# Altium schematic sheet Sheet1.SchDoc(Sheet1)
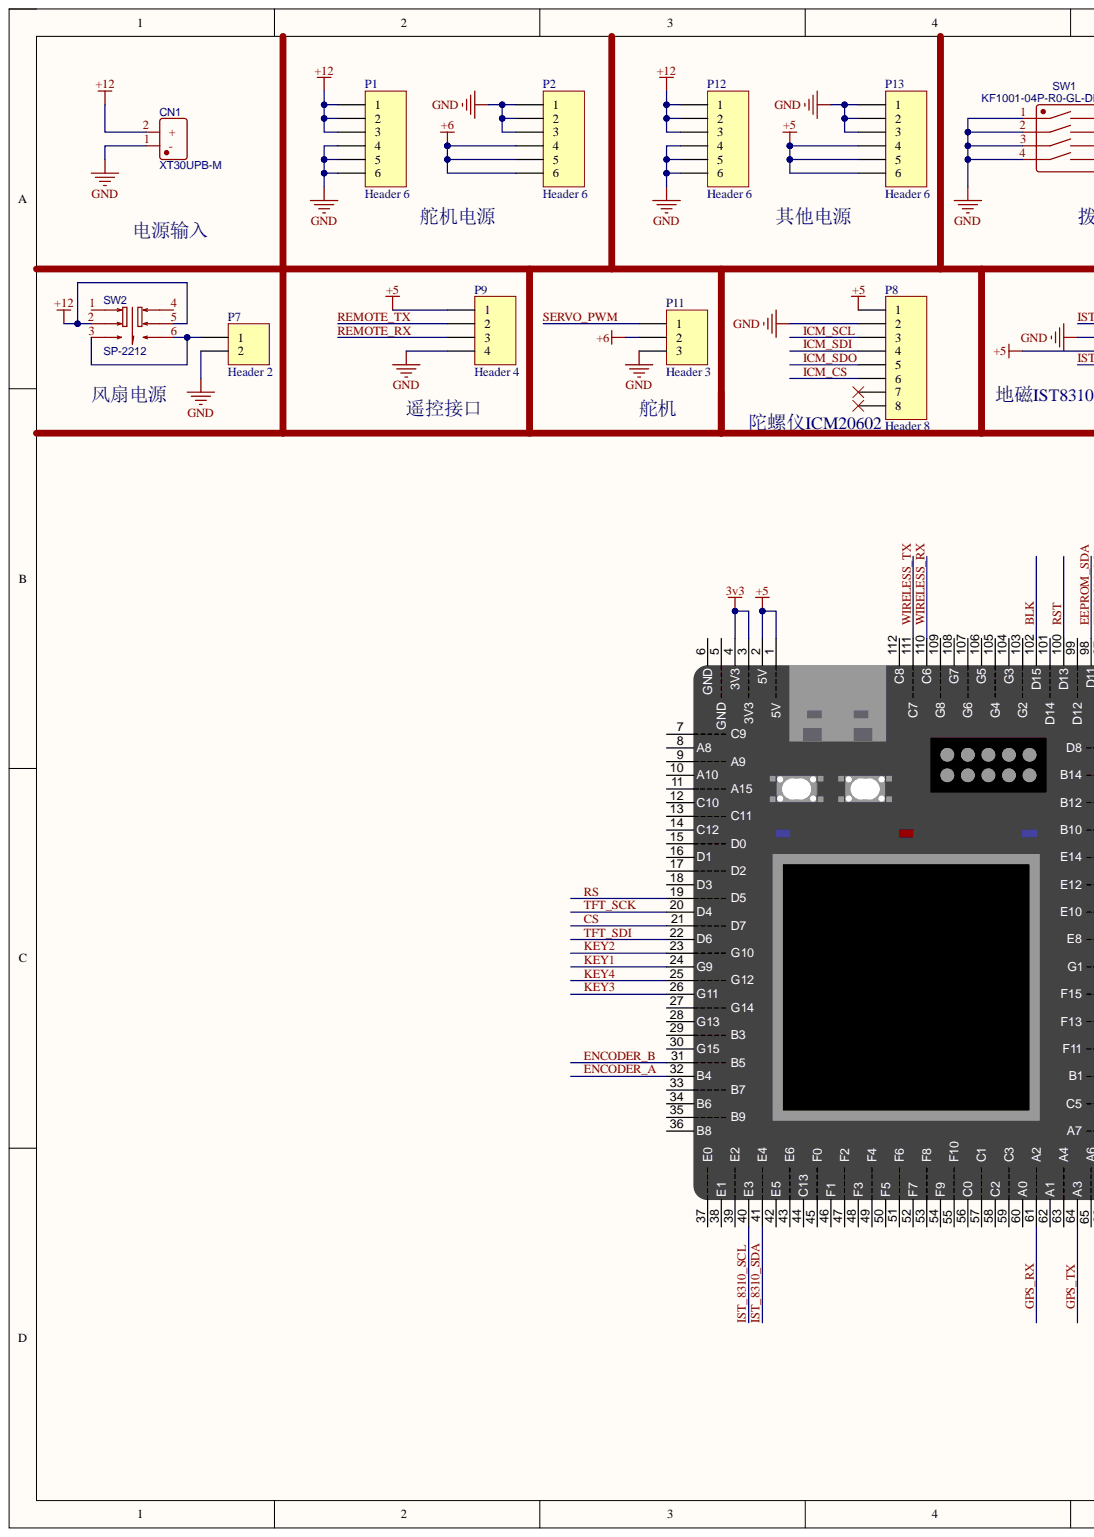
[diagram p0: Sheet1.SchDoc, left]
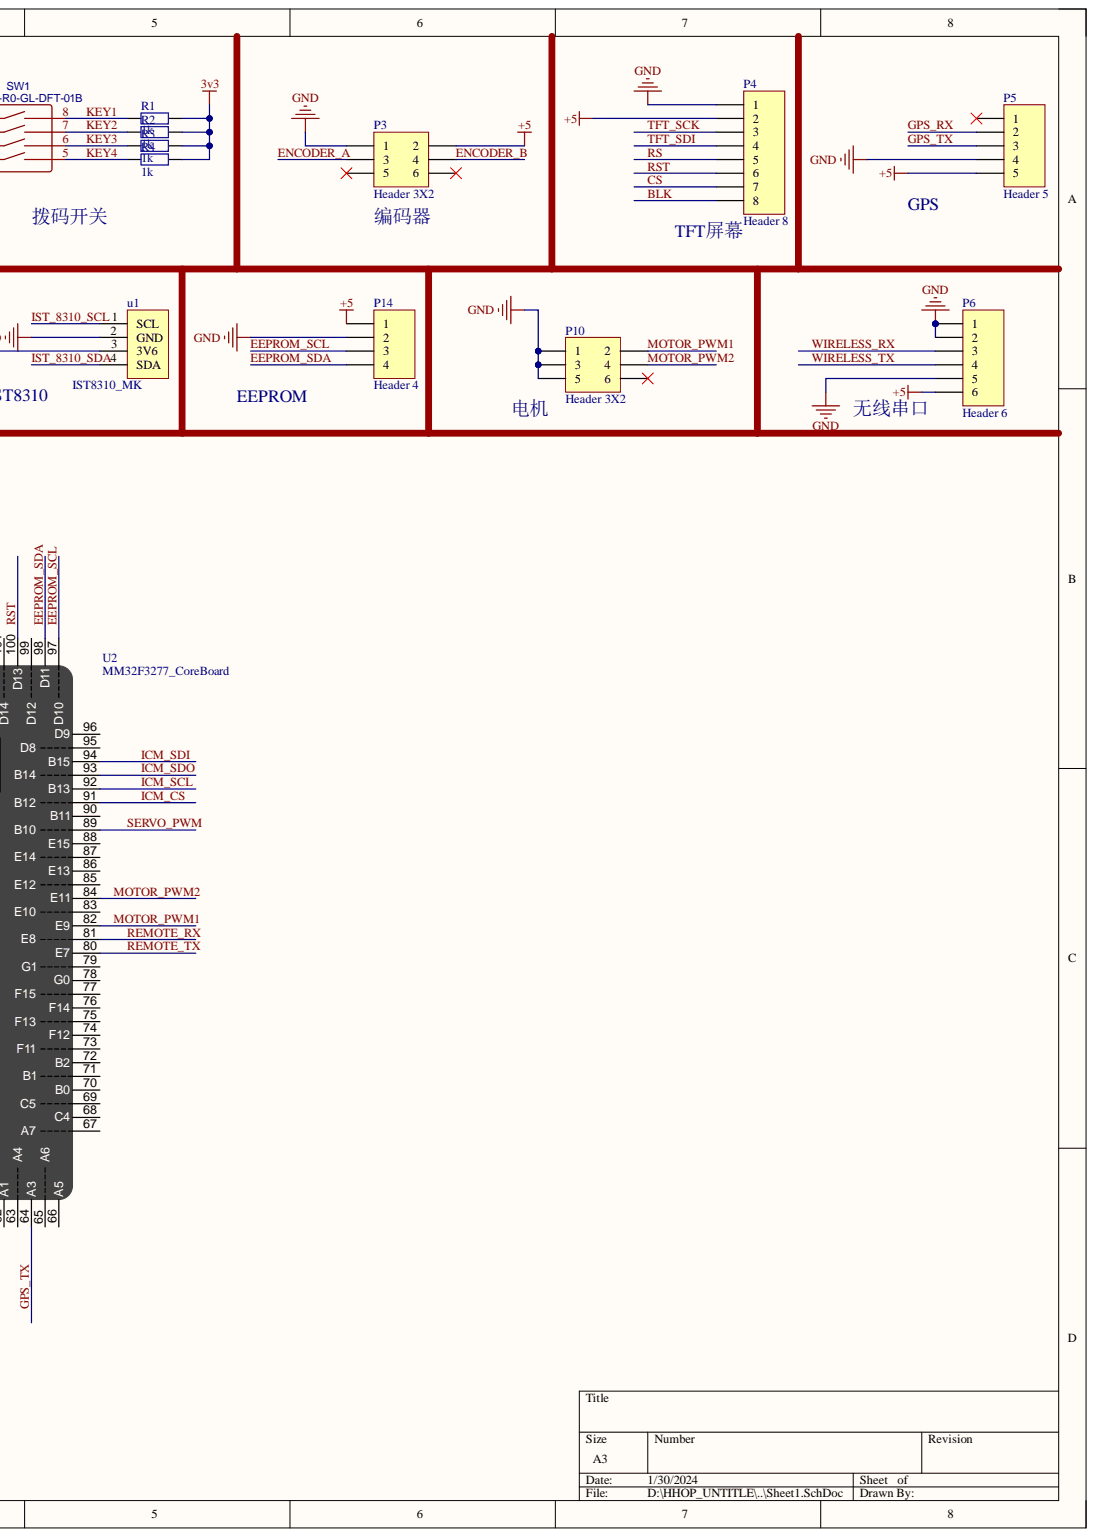
[diagram p1: Sheet1.SchDoc, right]

## Parts
CN1  XT30UPB-M  [CONN-TH_XT30UPB-M]
P1  Header 6  [HDR1X6]
P2  Header 6  [HDR1X6]
P3  Header 3X2  [HDR2X3]
P4  Header 8  [HDR1X8]
P5  Header 5  [HDR1X5]
P6  Header 6  [HDR1X6]
P7  Header 2  [HDR1X2]
P8  Header 8  [CONN-TH_XH-8A]
P9  Header 4  [HDR1X4]
P10  Header 3X2  [HDR2X3]
P11  Header 3  [HDR1X3]
P12  Header 6  [HDR1X6]
P13  Header 6  [HDR1X6]
P14  Header 4  [HDR1X4]
R1  1k  [0603_R]
R2  1k  [0603_R]
R3  1k  [0603_R]
R4  1k  [0603_R]
SW1  KF1001-04P-R0-GL-DFT-01B  [SW-TH_8P-L11.6-W10.0-P2.54-LS7.62]
SW2  SP-2212  [SW-TH_6P-L7.2-W7.2-P2.00-LS5.0]
u1  IST8310_MK  [ist8310]
U2  MM32F3277_CoreBoard  [MM32F3277_CoreBoard]

## Nets
3v3: R1.2 R2.2 R3.2 R4.2 U2.3 U2.4(3V3)
+5: P3.2 P4.2 P5.5 P6.6 P8.1 P9.1 P13.4 P13.5 P13.6 P14.1 u1.3(3V6) U2.1 U2.2(5V)
+6: P2.4 P2.5 P2.6 P11.2
+12: CN1.2 P1.1 P1.2 P1.3 P12.1 P12.2 P12.3 SW2.2 SW2.5
GND: CN1.1 P1.4 P1.5 P1.6 P2.1 P2.2 P2.3 P3.1 P4.1 P5.4 P6.1 P6.2 P6.5 P7.2 P8.2 P9.4 P10.1 P10.3 P10.5 P11.3 P12.4 P12.5 P12.6 P13.1 P13.2 P13.3 P14.2 SW1.1 SW1.2 SW1.3 SW1.4 u1.2(GND)
BLK: P4.8 U2.102(D15)
CS: P4.7 U2.21
EEPROM_SCL: P14.3 U2.97
EEPROM_SDA: P14.4 U2.98(D11)
ENCODER_A: P3.3 U2.32(B4)
ENCODER_B: P3.4 U2.31
GPS_RX: P5.2 U2.61
GPS_TX: P5.3 U2.64(A3)
ICM_CS: P8.6 U2.91
ICM_SCL: P8.3 U2.92(B13)
ICM_SDI: P8.4 U2.94(B15)
ICM_SDO: P8.5 U2.93
IST_8310_SCL: u1.1(SCL) U2.40(E3)
IST_8310_SDA: u1.4(SDA) U2.41
KEY1: R1.1 SW1.8 U2.24(G9)
KEY2: R2.1 SW1.7 U2.23
KEY3: R3.1 SW1.6 U2.26(G11)
KEY4: R4.1 SW1.5 U2.25
MOTOR_PWM1: P10.2 U2.82(E9)
MOTOR_PWM2: P10.4 U2.84(E11)
REMOTE_RX: P9.3 U2.81
REMOTE_TX: P9.2 U2.80(E7)
RS: P4.5 U2.19
RST: P4.6 U2.100(D13)
SERVO_PWM: P11.1 U2.89
TFT_SCK: P4.3 U2.20(D4)
TFT_SDI: P4.4 U2.22(D6)
WIRELESS_RX: P6.3 U2.110(C6)
WIRELESS_TX: P6.4 U2.111
NetP7_1: P7.1 SW2.3 SW2.6

Not connected: P3 5 6; P5 1; P8 7 8; P10 6; SW2 1 4; U2 5 6(GND) 7 8(A8) 9 10(A10) 11 12(C10) 13 14(C12) 15 16(D1) 17 18(D3) 27 28(G13) 29 30(G15) 33 34(B6) 35 36(B8) 37 38(E1) 39 42(E5) 43 44(C13) 45 46(F1) 47 48(F3) 49 50(F5) 51 52(F7) 53 54(F9) 55 56(C0) 57 58(C2) 59 60(A0) 62(A1) 63 65 66(A5) 67 68(C4) 69 70(B0) 71 72(B2) 73 74(F12) 75 76(F14) 77 78(G0) 79 83 85 86(E13) 87 88(E15) 90(B11) 95 96(D9) 99 101 103 104(G3) 105 106(G5) 107 108(G7) 109 112(C8)

## Library data of the parts
CN1 = XT30UPB-M: BOM_Manufacturer: Changzhou Amass Elec; Contributor: LCSC; BOM_JLCPCB Part Class: Extended Part; BOM_Manufacturer Part: XT30UPB-M; BOM_Supplier: LCSC; BOM_Supplier Part: C428721; Component Kind: Standard; Library Name: Schlib1.SchLib; spicePre: C; spiceSymbolName: XT30UPB-M
P1 P2 P6 P12 P13 = Header 6: Header, 6-Pin; Component Kind: Standard; LatestRevisionDate: 17-Jul-2002; LatestRevisionNote: Re-released for DXP Platform.; Library Name: Miscellaneous Connectors.IntLib; Signal Integrity: Connector; Publisher: Altium Limited
P3 P10 = Header 3X2: Header, 3-Pin, Dual row; Component Kind: Standard; LatestRevisionDate: 17-Jul-2002; LatestRevisionNote: Re-released for DXP Platform.; Library Name: Miscellaneous Connectors.IntLib; Signal Integrity: Connector; Publisher: Altium Limited
P4 P8 = Header 8: Header, 8-Pin; Component Kind: Standard; LatestRevisionDate: 17-Jul-2002; LatestRevisionNote: Re-released for DXP Platform.; Library Name: Miscellaneous Connectors.IntLib; Signal Integrity: Connector; Publisher: Altium Limited
P5 = Header 5: Header, 5-Pin; Component Kind: Standard; LatestRevisionDate: 17-Jul-2002; LatestRevisionNote: Re-released for DXP Platform.; Library Name: Miscellaneous Connectors.IntLib; Signal Integrity: Connector; Publisher: Altium Limited
P7 = Header 2: Header, 2-Pin; Component Kind: Standard; LatestRevisionDate: 17-Jul-2002; LatestRevisionNote: Re-released for DXP Platform.; Library Name: Miscellaneous Connectors.IntLib; Signal Integrity: Connector; Publisher: Altium Limited
P9 P14 = Header 4: Header, 4-Pin; Component Kind: Standard; LatestRevisionDate: 17-Jul-2002; LatestRevisionNote: Re-released for DXP Platform.; Library Name: Miscellaneous Connectors.IntLib; Signal Integrity: Connector; Publisher: Altium Limited
P11 = Header 3: Header, 3-Pin; Component Kind: Standard; LatestRevisionDate: 17-Jul-2002; LatestRevisionNote: Re-released for DXP Platform.; Library Name: Miscellaneous Connectors.IntLib; Signal Integrity: Connector; Publisher: Altium Limited
R1-R4 = Res_0603: 贴片电阻; Component Kind: Standard; ComponentLink1Description: 供应商链接; ComponentLink1URL: http://www.szlcsc.com/product/details_21903.html; Library Name: 02-Miscellaneous_Devices_ZSF_Library.IntLib; Package: 0603; Supplier: LC; SuppliersPartNumber: C21189
SW1 = KF1001-04P-R0-GL-DFT-01B: BOM_Manufacturer: 凯峰; Contributor: LCSC; BOM_JLCPCB Part Class: Extended Part; BOM_Manufacturer Part: KF1001-04P-R0-GL-DFT-01B; BOM_Supplier: LCSC; BOM_Supplier Part: C976326; Component Kind: Standard; spicePre: S; spiceSymbolName: KF1001-04P-R0-GL-DFT-01B
SW2 = SP-2212: BOM_Manufacturer: RI SHENG; Contributor: LCSC; BOM_JLCPCB Part Class: Extended Part; BOM_Manufacturer Part: SP-2212; BOM_Supplier: LCSC; BOM_Supplier Part: C589287; Component Kind: Standard; spicePre: S; spiceSymbolName: SP-2212
U2 = MM32F3277_CoreBoard: 逐飞科技MM32F3277核心板; Component Kind: Standard; Library Name: MM32F3277核心板整体原理.SchLib
u1 = IST8310_MK: Component Kind: Standard; Library Name: Schlib1.SchLib
